# Altium schematic sheet Main.SchDoc
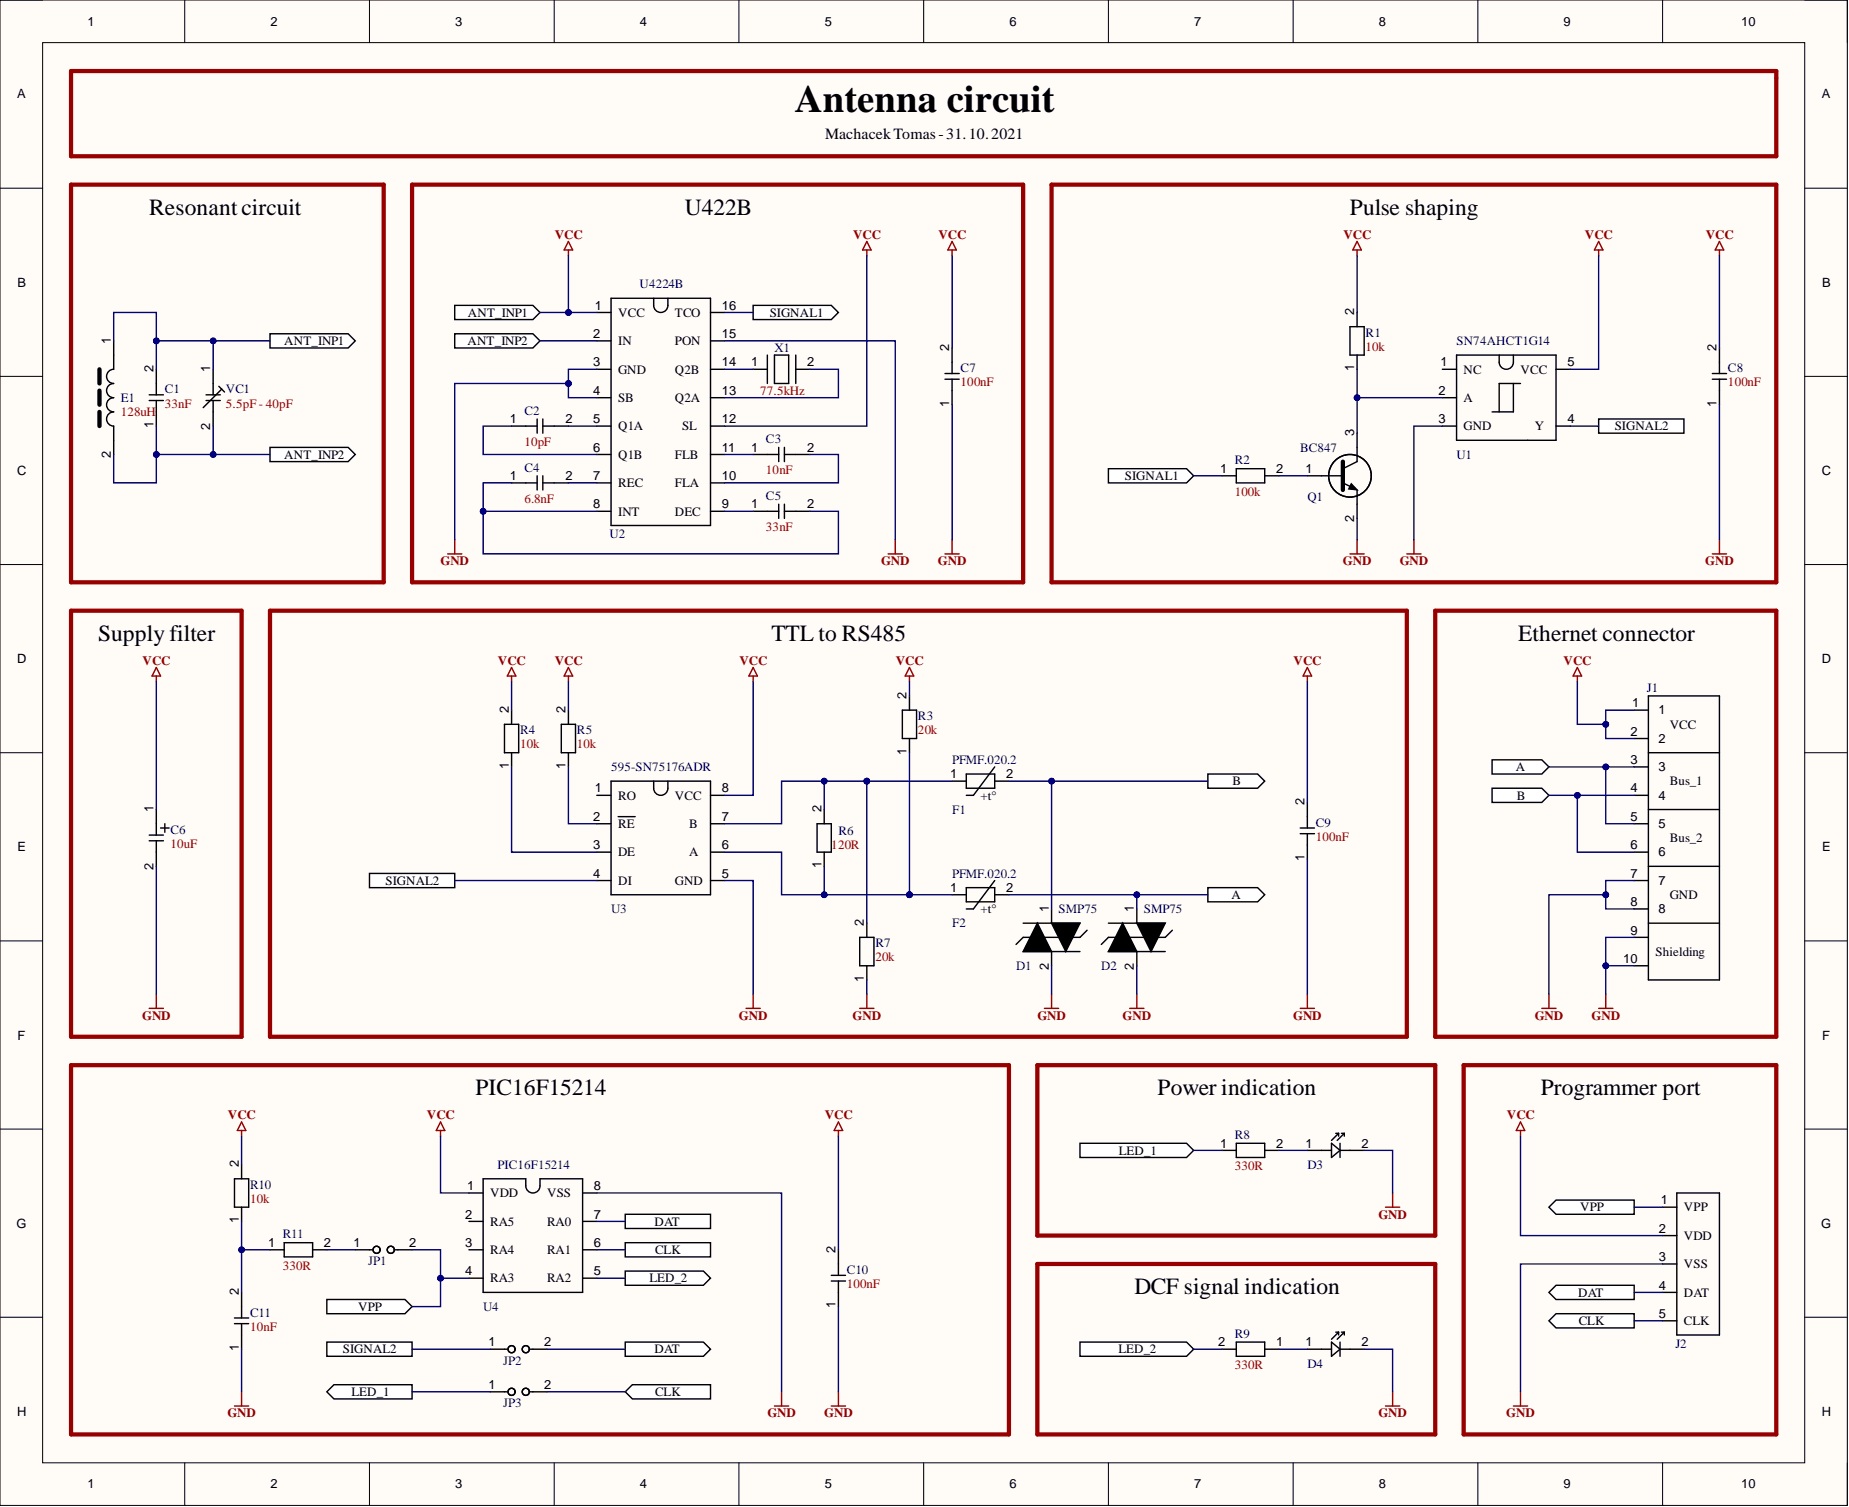
[diagram p0: Main.SchDoc]

## Parts
C1  value 33nF  [Film_capacitator]  (hidden comment: Capacitator_film)
C2  value 10pF  [MLCC_capacitator]  (hidden comment: Capacitator_MLCC)
C3  value 10nF  [MLCC_capacitator]  (hidden comment: Capacitator_MLCC)
C4  value 6.8nF  [MLCC_capacitator]  (hidden comment: Capacitator_MLCC)
C5  value 33nF  [MLCC_capacitator]  (hidden comment: Capacitator_MLCC)
C6  value 10uF  [Capacitator_aluminium]  (hidden comment: Capacitator_aluminium)
C7  value 100nF  [MLCC_capacitator]  (hidden comment: Capacitator_MLCC)
C8  value 100nF  [MLCC_capacitator]  (hidden comment: Capacitator_MLCC)
C9  value 100nF  [MLCC_capacitator]  (hidden comment: Capacitator_MLCC)
C10  value 100nF  [MLCC_capacitator]  (hidden comment: Capacitator_MLCC)
C11  value 10nF  [MLCC_capacitator]  (hidden comment: Capacitator_MLCC)
D1  SMP75  [SMP75]
D2  SMP75  [SMP75]
D3  Indication_led  [indication_led]  (value not drawn)
D4  Indication_led  [indication_led]  (value not drawn)
E1  value 128uH  [Antenna]  (hidden comment: Ferrite_antenna)
F1  PFMF.020.2  [PFMF.020.2]
F2  PFMF.020.2  [PFMF.020.2]
J1  Ethernet_connector  [Ethernet_connector]
J2  Programmer_port  [Programmer_port]
JP1  Jumper  [Jumper]  (value not drawn)
JP2  Jumper  [Jumper]  (value not drawn)
JP3  Jumper  [Jumper]  (value not drawn)
Q1  BC847  [BC847]
R1  value 10k  [Resistor]  (hidden comment: Resistor)
R2  value 100k  [Resistor]  (hidden comment: Resistor)
R3  value 20k  [Resistor]  (hidden comment: Resistor)
R4  value 10k  [Resistor]  (hidden comment: Resistor)
R5  value 10k  [Resistor]  (hidden comment: Resistor)
R6  value 120R  [Resistor]  (hidden comment: Resistor)
R7  value 20k  [Resistor]  (hidden comment: Resistor)
R8  value 330R  [Resistor]  (hidden comment: Resistor)
R9  value 330R  [Resistor]  (hidden comment: Resistor)
R10  value 10k  [Resistor]  (hidden comment: Resistor)
R11  value 330R  [Resistor]  (hidden comment: Resistor)
U1  SN74AHCT1G14  [SN74AHCT1G14]
U2  U4224B  [U422B]
U3  SN75176A  Supplier Part Number 1: 595-SN75176ADR  [SN75176A]
U4  PIC16F15214  [PIC16F15214]
VC1  value 5.5pF - 40pF  [Trimmer_capacitator]  (hidden comment: Trimmer_capacitator)
X1  value 77.5kHz  [Crystal]  (hidden comment: Crystal)

## Ports
A, ANT_INP1, ANT_INP2, B, CLK, DAT, LED_1, LED_2, SIGNAL1, SIGNAL2, VPP

## Nets
GND: C6.2 C7.1 C8.1 C9.1 C10.1 C11.1 D1.2 D2.2 D3.2 D4.2 J1.7 J1.8 J1.9 J1.10 J2.3(VSS) Q1.2 R7.1 U1.3(GND) U2.3(GND) U2.4(SB) U2.15(PON) U3.5(GND) U4.8(VSS)
A: D2.1 F2.2 J1.3 J1.5 ; port A
ANT_INP2: C1.1 E1.2 U2.2(IN) VC1.2 ; port ANT_INP2
B: D1.1 F1.2 J1.4 J1.6 ; port B
CLK: J2.5(CLK) JP3.2 U4.6(RA1) ; port CLK
DAT: J2.4(DAT) JP2.2 U4.7(RA0) ; port DAT
LED_1: JP3.1 R8.1 ; port LED_1
LED_2: R9.2 U4.5(RA2) ; port LED_2
SIGNAL1: R2.1 U2.16(TCO) ; port SIGNAL1
SIGNAL2: JP2.1 U1.4(Y) U3.4(DI) ; port SIGNAL2
VCC: C1.2 C6.1 C7.2 C8.2 C9.2 C10.2 E1.1 J1.1 J1.2 J2.2(VDD) R1.2 R3.2 R4.2 R5.2 R10.2 U1.5(VCC) U2.1(VCC) U2.12(SL) U3.8(VCC) U4.1(VDD) VC1.1 ; port ANT_INP1
VPP: J2.1(VPP) JP1.2 U4.4(RA3) ; port VPP
NetC2_1: C2.1 U2.6(Q1B)
NetC2_2: C2.2 U2.5(Q1A)
NetC3_1: C3.1 U2.11(FLB)
NetC3_2: C3.2 U2.10(FLA)
NetC4_1: C4.1 C5.2 U2.8(INT)
NetC4_2: C4.2 U2.7(REC)
NetC5_1: C5.1 U2.9(DEC)
NetC11_2: C11.2 R10.1 R11.1
NetD3_1: D3.1 R8.2
NetD4_1: D4.1 R9.1
NetF1_1: F1.1 R6.2 R7.2 U3.7(B)
NetF2_1: F2.1 R3.1 R6.1 U3.6(A)
NetJP1_1: JP1.1 R11.2
NetQ1_1: Q1.1 R2.2
NetQ1_3: Q1.3 R1.1 U1.2(A)
NetR4_1: R4.1 U3.3(DE)
NetR5_1: R5.1 U3.2(RE)
NetU2_13: U2.13(Q2A) X1.2
NetU2_14: U2.14(Q2B) X1.1

Not connected: U1 1(NC); U3 1(RO); U4 2(RA5) 3(RA4)

## Library data of the parts
C1 = Capacitator_film: Film capacitator; Component Kind: Standard; Library Name: Schematic_library.SchLib; Supplier 1: Mouser; Supplier Part Number 1: 505-SMDID02330KA00KP
C2-C5 C7-C11 = Capacitator_MLCC: MLCC capacitator - 1206; Component Kind: Standard; Library Name: Schematic_library.SchLib
C6 = Capacitator_aluminium: Aluminum capacitator - filtration; Component Kind: Standard; Library Name: Schematic_library.SchLib; Supplier 1: Mouser; Supplier 2: Mouser; Supplier 3: Mouser; Supplier Part Number 3: 80-EDK106M035A9BAA
D1 D2 = SMP75: Bidirectional crowbar protection; Component Kind: Standard; Library Name: Schematic_library.SchLib; Supplier 1: Mouser; Supplier Part Number 1: 511-SMP75-8
D3 D4 = Indication_led: Indication led to panel; Component Kind: Standard; Library Name: Schematic_library.SchLib; Supplier 1: Mouser; Supplier Part Number 1: 593-L63DR2L
E1 = Ferrite_antenna: Ferrite antenna; Component Kind: Standard; Library Name: Schematic_library.SchLib
F1 F2 = PFMF.020.2: SMD fuse; Component Kind: Standard; Library Name: Schematic_library.SchLib; Supplier 1: Mouser; Supplier Part Number 1: 693-PFMF.020.2
J1 = Ethernet_connector: Ethernet connector; Component Kind: Standard; Library Name: Schematic_library.SchLib; Supplier 1: Mouser; Supplier Part Number 1: 571-6368150-1
J2 = Programmer_port: Programmer port for PIC; Component Kind: Standard; Library Name: Schematic_library.SchLib
JP1-JP3 = Jumper: Solderable jumper; Component Kind: Standard; Library Name: Schematic_library.SchLib
Q1 = BC847: NPN transistor; Component Kind: Standard; Library Name: Schematic_library.SchLib; Supplier 1: Mouser; Supplier Part Number 1: 771-BC847215
R1-R11 = Resistor: SMD resistor - 1206; Component Kind: Standard; Library Name: Schematic_library.SchLib
U1 = SN74AHCT1G14: SINGLE SCHMITT-TRIGGER INVERTER GATE; Component Kind: Standard; Library Name: Schematic_library.SchLib; Supplier 1: Mouser; Supplier Part Number 1: 595-SN74AHCT1G14DBVR
U2 = U4224B: DCF77 signal decoder; Component Kind: Standard; Library Name: Schematic_library.SchLib
U3 = SN75176A: RS485 transreciever; Component Kind: Standard; Library Name: Schematic_library.SchLib; Supplier 1: Mouser
U4 = PIC16F15214: 8-bit microcontroller; Component Kind: Standard; Library Name: Schematic_library.SchLib; Supplier 1: Mouser; Supplier Part Number 1: 579-PIC16F15214-I/SN
VC1 = Trimmer_capacitator: Film dielectric trimmer; Component Kind: Standard; Library Name: Schematic_library.SchLib; Supplier 1: Mouser; Supplier Part Number 1: 594-2222-808-31409
X1 = Crystal: Quartz crystal; Component Kind: Standard; Library Name: Schematic_library.SchLib
